# Altium schematic sheet CVTOP.SchDoc(CVTOP)
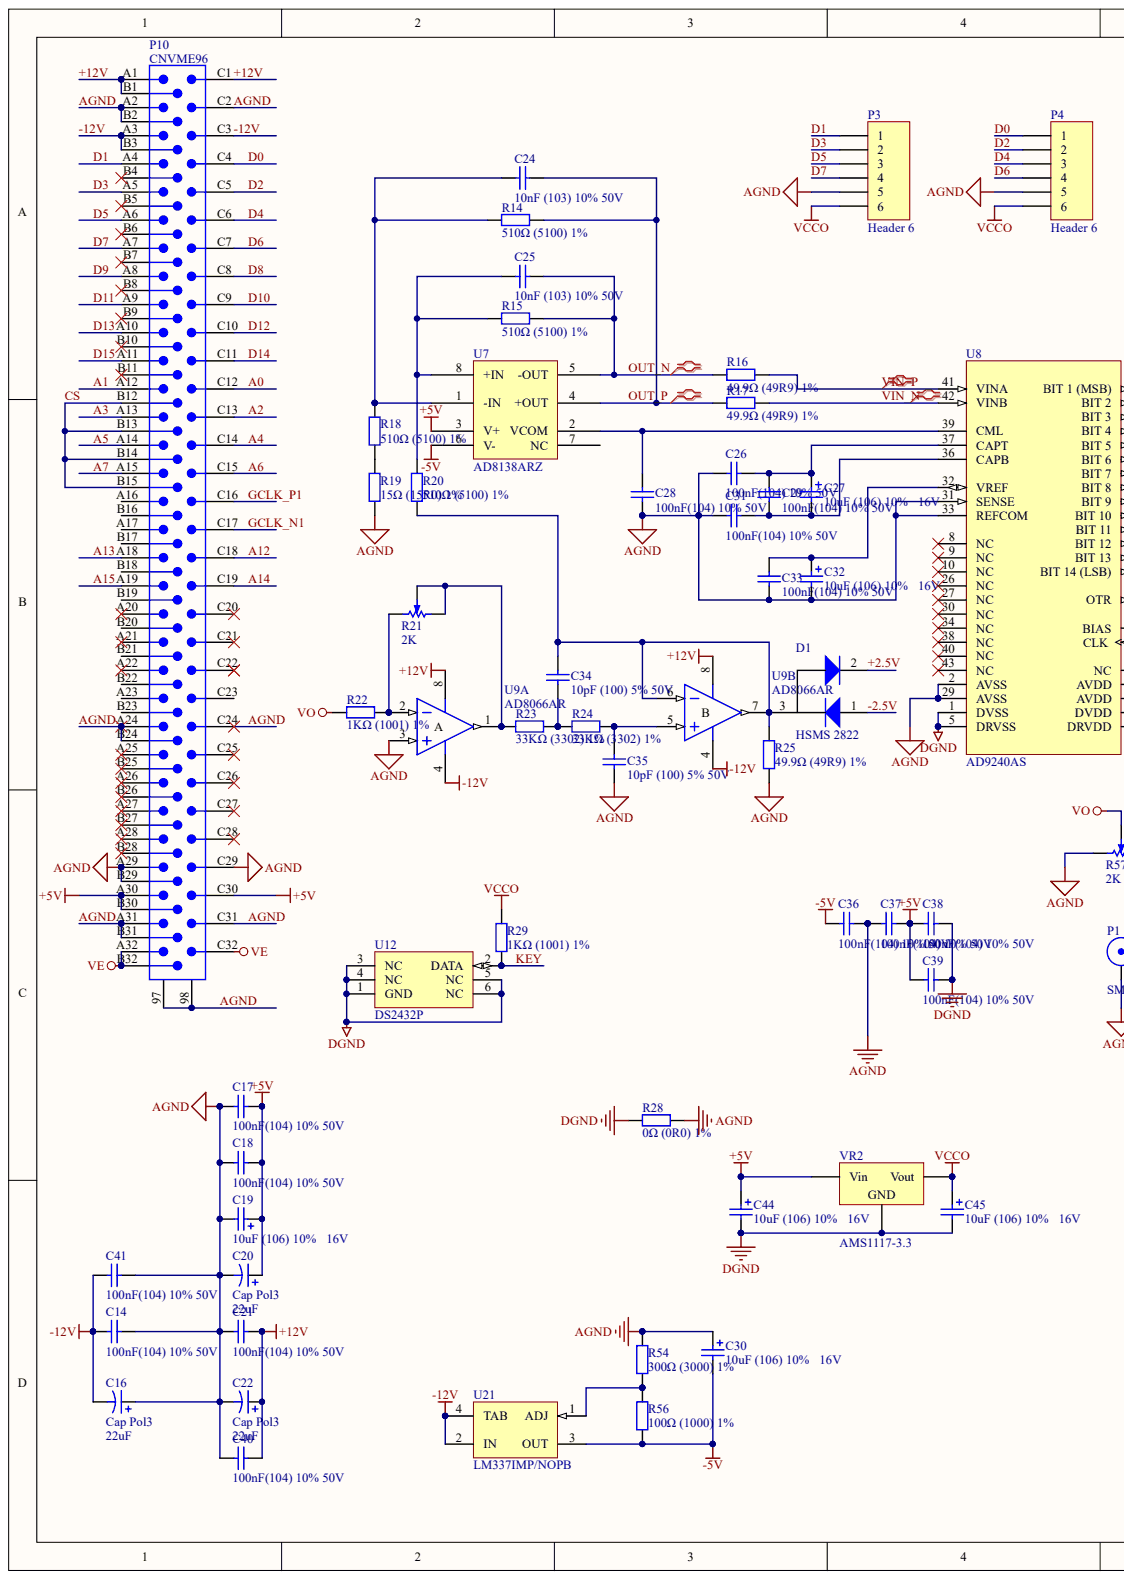
[diagram p0: CVTOP.SchDoc, left]
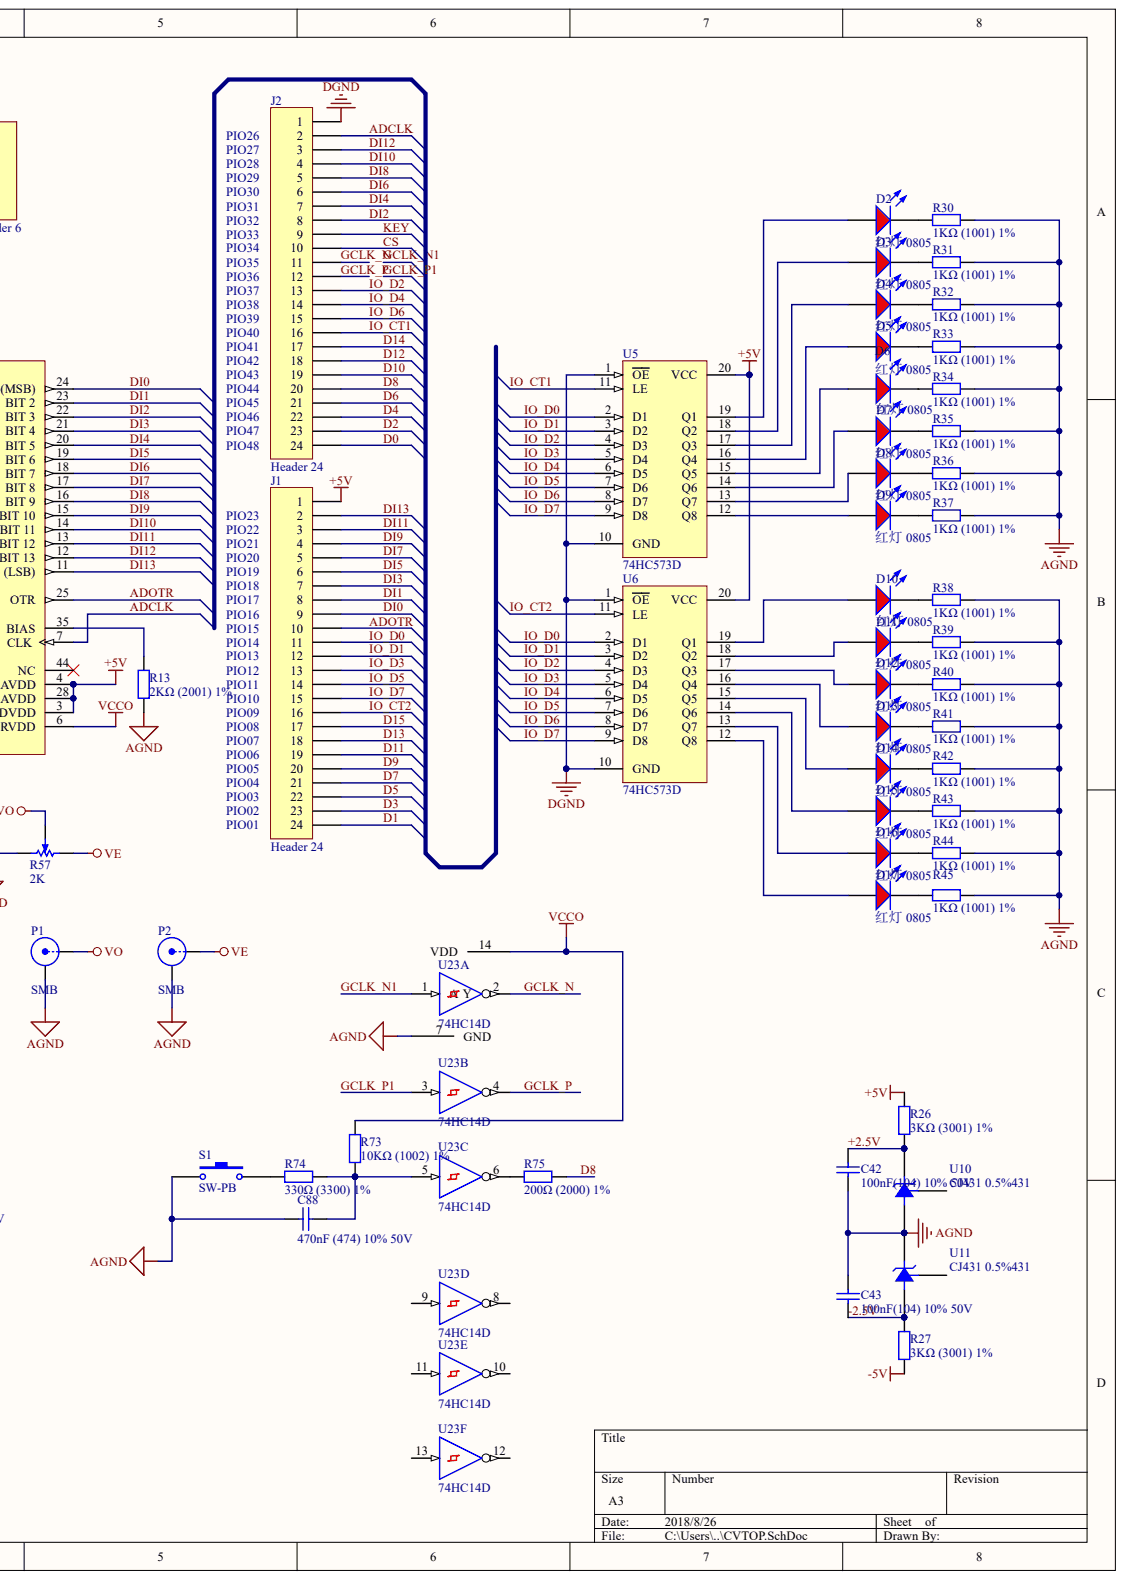
[diagram p1: CVTOP.SchDoc, right]

## Parts
C14  100nF(104) 10% 50V
C16  Cap Pol3  value 22uF
C17  100nF(104) 10% 50V
C18  100nF(104) 10% 50V
C19  10uF (106) ±10% 16V
C20  Cap Pol3  value 22uF
C21  100nF(104) 10% 50V
C22  Cap Pol3  value 22uF
C24  10nF (103) 10% 50V
C25  10nF (103) 10% 50V
C26  100nF(104) 10% 50V
C27  10uF (106) ±10% 16V
C28  100nF(104) 10% 50V
C29  100nF(104) 10% 50V
C30  10uF (106) ±10% 16V
C31  100nF(104) 10% 50V
C32  10uF (106) ±10% 16V
C33  100nF(104) 10% 50V
C34  10pF (100) 5% 50V
C35  10pF (100) 5% 50V
C36  100nF(104) 10% 50V
C37  100nF(104) 10% 50V
C38  100nF(104) 10% 50V
C39  100nF(104) 10% 50V
C40  100nF(104) 10% 50V
C41  100nF(104) 10% 50V
C42  100nF(104) 10% 50V
C43  100nF(104) 10% 50V
C44  10uF (106) ±10% 16V
C45  10uF (106) ±10% 16V
C88  470nF (474) 10% 50V
D1  HSMS 2822
D2  红灯 0805  Package: 0805
D3  红灯 0805  Package: 0805
D4  红灯 0805  Package: 0805
D5  红灯 0805  Package: 0805
D6  红灯 0805  Package: 0805
D7  红灯 0805  Package: 0805
D8  红灯 0805  Package: 0805
D9  红灯 0805  Package: 0805
D10  红灯 0805  Package: 0805
D11  红灯 0805  Package: 0805
D12  红灯 0805  Package: 0805
D13  红灯 0805  Package: 0805
D14  红灯 0805  Package: 0805
D15  红灯 0805  Package: 0805
D16  红灯 0805  Package: 0805
D17  红灯 0805  Package: 0805
J1  Header 24
J2  Header 24
P1  SMB
P2  SMB
P3  Header 6
P4  Header 6
P10  CNVME96
R13  2KΩ (2001) ±1%  (value not drawn)
R14  510Ω (5100) ±1%
R15  510Ω (5100) ±1%
R16  49.9Ω (49R9) ±1%
R17  49.9Ω (49R9) ±1%
R18  510Ω (5100) ±1%
R19  15Ω (15R0) ±1%  (value not drawn)
R20  510Ω (5100) ±1%
R21  2K
R22  1KΩ (1001) ±1%
R23  33KΩ (3302) ±1%  (value not drawn)
R24  33KΩ (3302) ±1%  (value not drawn)
R25  49.9Ω (49R9) ±1%
R26  3KΩ (3001) ±1%  (value not drawn)
R27  3KΩ (3001) ±1%  (value not drawn)
R28  0Ω (0R0) ±1%
R29  1KΩ (1001) ±1%  (value not drawn)
R30  1KΩ (1001) ±1%
R31  1KΩ (1001) ±1%  Package: 0805
R32  1KΩ (1001) ±1%  Package: 0805
R33  1KΩ (1001) ±1%  Package: 0805
R34  1KΩ (1001) ±1%  Package: 0805
R35  1KΩ (1001) ±1%  Package: 0805
R36  1KΩ (1001) ±1%  Package: 0805
R37  1KΩ (1001) ±1%  Package: 0805
R38  1KΩ (1001) ±1%
R39  1KΩ (1001) ±1%  Package: 0805
R40  1KΩ (1001) ±1%  Package: 0805
R41  1KΩ (1001) ±1%  Package: 0805
R42  1KΩ (1001) ±1%  Package: 0805
R43  1KΩ (1001) ±1%  Package: 0805
R44  1KΩ (1001) ±1%  Package: 0805
R45  1KΩ (1001) ±1%  Package: 0805
R54  300Ω (3000) ±1%
R56  100Ω (1000) ±1%
R57  2K
R73  10KΩ (1002) ±1%
R74  330Ω (3300) ±1%
R75  200Ω (2000) ±1%
S1  SW-PB
U5  74HC573D
U6  74HC573D
U7  AD8138ARZ
U8  AD9240AS
U9  AD8066AR  (parts A,B)
U10  CJ431 0.5%431
U11  CJ431 0.5%431
U12  DS2432P
U21  LM337IMP/NOPB
U23  74HC14D  (parts A,B,C,D,E,F)
VR2  AMS1117-3.3

## Nets
+5V: C17.2 C18.2 C19.1 C20.1 C37.1 C38.2 C39.2 C44.1 J1.1 P10.A30 P10.B30 P10.C30 R26.2 U5.20(VCC) U6.20(VCC) U7.3(V+) U8.3(DVDD) U8.4(AVDD) U8.28(AVDD) VR2.3
-5V: C30.2 C36.2 R27.1 R56.1 U7.6(V-) U21.3(OUT)
DGND: C38.1 C39.1 C44.2 C45.2 J2.1 R28.1 U5.1(OE) U5.10(GND) U6.1(OE) U6.10(GND) U8.1(DVSS) U8.5(DRVSS) U12.1(GND) U12.3(NC) U12.4(NC) U12.5(NC) U12.6(NC) VR2.1
VCCO: C45.1 P3.6 P4.6 R29.2 R73.1 U8.6(DRVDD) U23.14(VDD) VR2.2
VE: P2.1 P10.A32 P10.B32 P10.C32 R57.CCW
VO: P1.1 R22.1 R57.W
+2.5V: C42.1 D1.2 R26.1 U10.2
+12V: C21.2 C22.1 C40.1 P10.A1 P10.B1 P10.C1 U9.8
-2.5V: C43.2 D1.1 R27.2 U11.3
-12V: C14.1 C16.2 C41.2 P10.A3 P10.B3 P10.C3 U9.4 U21.2(IN) U21.4(TAB)
A0: P10.C12
A1: P10.A12
A2: P10.C13
A3: P10.A13
A4: P10.C14
A5: P10.A14
A6: P10.C15
A7: P10.A15
A12: P10.C18
A13: P10.A18
A14: P10.C19
A15: P10.A19
ADCLK: J2.2 U8.7(CLK)
ADOTR: J1.10 U8.25(OTR)
AGND: C14.2 C16.1 C17.1 C18.1 C19.2 C20.2 C21.1 C22.2 C26.2 C28.1 C30.1 C31.2 C32.2 C33.1 C35.1 C36.1 C37.2 C40.2 C41.1 C42.2 C43.1 C88.1 P1.2 P2.2 P3.5 P4.5 P10.97 P10.98 P10.A2 P10.A24 P10.A29 P10.A31 P10.B2 P10.B24 P10.B29 P10.B31 P10.C2 P10.C24 P10.C29 P10.C31 R13.1 R19.1 R25.1 R28.2 R30.2 R31.2 R32.2 R33.2 R34.2 R35.2 R36.2 R37.2 R38.2 R39.2 R40.2 R41.2 R42.2 R43.2 R44.2 R45.2 R54.2 R57.CW S1.1 U8.2(AVSS) U8.29(AVSS) U8.31(SENSE) U8.33(REFCOM) U9.3 U10.3 U11.2 U23.7(GND)
CS: J2.10 P10.B12 P10.B13 P10.B14 P10.B15
D0: J2.24 P4.1 P10.C4
D1: J1.24 P3.1 P10.A4
D2: J2.23 P4.2 P10.C5
D3: J1.23 P3.2 P10.A5
D4: J2.22 P4.3 P10.C6
D5: J1.22 P3.3 P10.A6
D6: J2.21 P4.4 P10.C7
D7: J1.21 P3.4 P10.A7
D8: J2.20 P10.C8 R75.2
D9: J1.20 P10.A8
D10: J2.19 P10.C9
D11: J1.19 P10.A9
D12: J2.18 P10.C10
D13: J1.18 P10.A10
D14: J2.17 P10.C11
D15: J1.17 P10.A11
DI0: J1.9 U8.24(BIT_1_(MSB))
DI1: J1.8 U8.23(BIT_2)
DI2: J2.8 U8.22(BIT_3)
DI3: J1.7 U8.21(BIT_4)
DI4: J2.7 U8.20(BIT_5)
DI5: J1.6 U8.19(BIT_6)
DI6: J2.6 U8.18(BIT_7)
DI7: J1.5 U8.17(BIT_8)
DI8: J2.5 U8.16(BIT_9)
DI9: J1.4 U8.15(BIT_10)
DI10: J2.4 U8.14(BIT_11)
DI11: J1.3 U8.13(BIT_12)
DI12: J2.3 U8.12(BIT_13)
DI13: J1.2 U8.11(BIT_14_(LSB))
GCLK_N: J2.11 U23.2(Y)
GCLK_N1: P10.C17 U23.1(A)
GCLK_P: J2.12 U23.4
GCLK_P1: P10.C16 U23.3
IO_CT1: J2.16 U5.11(LE)
IO_CT2: J1.16 U6.11(LE)
IO_D0: J1.11 U5.2(D1) U6.2(D1)
IO_D1: J1.12 U5.3(D2) U6.3(D2)
IO_D2: J2.13 U5.4(D3) U6.4(D3)
IO_D3: J1.13 U5.5(D4) U6.5(D4)
IO_D4: J2.14 U5.6(D5) U6.6(D5)
IO_D5: J1.14 U5.7(D6) U6.7(D6)
IO_D6: J2.15 U5.8(D7) U6.8(D7)
IO_D7: J1.15 U5.9(D8) U6.9(D8)
KEY: J2.9 R29.1 U12.2(DATA)
OUT_N: C25.2 R15.2 R16.1 U7.5(-OUT)
OUT_P: C24.2 R14.2 R17.1 U7.4(+OUT)
VIN_N: R17.2 U8.42(VINB)
VIN_P: R16.2 U8.41(VINA)
NetC24_1: C24.1 R14.1 R18.2 U7.1(-IN)
NetC25_1: C25.1 R15.1 R20.2 U7.8(+IN)
NetC26_1: C26.1 C27.1 C29.2 U8.37(CAPT)
NetC27_2: C27.2 C29.1 C31.1 U8.36(CAPB)
NetC28_2: C28.2 U7.2(VCOM) U8.39(CML)
NetC32_1: C32.1 C33.2 U8.32(VREF)
NetC34_1: C34.1 R23.2 R24.1
NetC34_2: C34.2 D1.3 R20.1 R25.2 U9.6 U9.7
NetC35_2: C35.2 R24.2 U9.5
NetC88_2: C88.2 R73.2 R74.2 U23.5
NetD2_A: D2.A U5.19(Q1)
NetD2_K: D2.K R30.1
NetD3_A: D3.A U5.18(Q2)
NetD3_K: D3.K R31.1
NetD4_A: D4.A U5.17(Q3)
NetD4_K: D4.K R32.1
NetD5_A: D5.A U5.16(Q4)
NetD5_K: D5.K R33.1
NetD6_A: D6.A U5.15(Q5)
NetD6_K: D6.K R34.1
NetD7_A: D7.A U5.14(Q6)
NetD7_K: D7.K R35.1
NetD8_A: D8.A U5.13(Q7)
NetD8_K: D8.K R36.1
NetD9_A: D9.A U5.12(Q8)
NetD9_K: D9.K R37.1
NetD10_A: D10.A U6.19(Q1)
NetD10_K: D10.K R38.1
NetD11_A: D11.A U6.18(Q2)
NetD11_K: D11.K R39.1
NetD12_A: D12.A U6.17(Q3)
NetD12_K: D12.K R40.1
NetD13_A: D13.A U6.16(Q4)
NetD13_K: D13.K R41.1
NetD14_A: D14.A U6.15(Q5)
NetD14_K: D14.K R42.1
NetD15_A: D15.A U6.14(Q6)
NetD15_K: D15.K R43.1
NetD16_A: D16.A U6.13(Q7)
NetD16_K: D16.K R44.1
NetD17_A: D17.A U6.12(Q8)
NetD17_K: D17.K R45.1
NetR13_2: R13.2 U8.35(BIAS)
NetR18_1: R18.1 R19.2
NetR21_CCW: R21.CCW R21.W R23.1 U9.1
NetR21_CW: R21.CW R22.2 U9.2
NetR54_1: R54.1 R56.2 U21.1(ADJ)
NetR74_1: R74.1 S1.2
NetR75_1: R75.1 U23.6
N00176:

Not connected: P10 A16 A17 A20 A21 A22 A23 A25 A26 A27 A28 B4 B5 B6 B7 B8 B9 B10 B11 B16 B17 B18 B19 B20 B21 B22 B23 B25 B26 B27 B28 C20 C21 C22 C23 C25 C26 C27 C28; U7 7(NC); U8 8(NC) 9(NC) 10(NC) 26(NC) 27(NC) 30(NC) 34(NC) 38(NC) 40(NC) 43(NC) 44(NC); U10 1; U11 1; U23 8 9 10 11 12 13

## Library data of the parts
C20 C22 = (no symbol name): Value: 22uF; Published: 8-Jun-2000; LatestRevisionDate: 29-May-2009; LatestRevisionNote: IPC-7351 Footprint Added.; PackageReference: C0805; PackageDescription: Chip Capacitor; Publisher: Altium Limited
P10 = (no symbol name): Text Field6: Alternate Layout Available; Text Field7: Published 14-Feb-2002
U12 = (no symbol name): Note: Pin numbers 3-6 are Not Connected (NC); Published: 13-Oct-2000; LatestRevisionDate: 17-Jul-2002; LatestRevisionNote: Re-released for DXP Platform.; DatasheetVersion: 21-Oct-1999; PackageVersion: 1999; PackageReference: TSOC6; PackageDescription: Small Outline; 6 C-Bend Leads; Body Width 3.8 mm; Pitch 1.27 mm; ComponentLink1Description: Manufacturer Link; ComponentLink1URL: http://www.maxim-ic.com/; ComponentLink2Description: Datasheet; ComponentLink2URL: http://pdfserv.maxim-ic.com/en/ds/DS2430A.pdf; Publisher: Altium Limited
U21 = (no symbol name): Manufacturer: National Semiconductor; PackageTopMarking: XYTT*N02A; PowerWise: N; CompType: LDO IC; Published: 1-Mar-2010; DatasheetVersion: Nov-2004; PackageVersion: revB, Apr-2003; PackageReference: MP04A; PackageDescription: Molded SOT-223, 6.50 x 3.56 x 1.6mm Body, 4 Lead, 2.3mm Pitch; Supplier 1: Digi-Key; Supplier Part Number 1: LM337IMPTR-ND; Supplier 2: Digi-Key; Supplier Part Number 2: LM337IMPCT-ND; Supplier 3: Digi-Key; Supplier Part Number 3: LM337IMPDKR-ND; Supplier 4: Newark; Supplier Part Number 4: 41K4742; PartNumber: LM337IMP/NOPB; RoHS: Y; PinCount: 4; Code_JEDEC: TO-261-AA; Code_IPC: SOT223-4; ComponentLink1Description: LM337 Datasheet; ComponentLink1URL: http://www.national.com/ds/LM/LM337.pdf; ComponentLink2Description: LM337 Web Page; ComponentLink2URL: http://www.national.com/pf/LM/LM337.html; ComponentLink3Description: MP04A Package Page; ComponentLink3URL: http://www.national.com/packaging/folders/mp04a.html; Publisher: Altium Limited
C14 C16-C19 C21 C24-C45 C88 D1-D17 J1 J2 P1-P4 R13-R45 R54 R56 R57 R73-R75 S1 U5-U11 U23 VR2 = (no symbol name)
  C14 C17 C18 C21 C26 C28 C29 C31 C33 C36-C43: ComponentLink1Description: 供应商链接; ComponentLink1URL: http://www.szlcsc.com/product/details_50686.html; Package: 0805; Supplier: LC; SuppliersPartNumber: C49678
  C16: Published: 8-Jun-2000; LatestRevisionDate: 29-May-2009; LatestRevisionNote: IPC-7351 Footprint Added.; PackageReference: C0805; PackageDescription: Chip Capacitor
  C19 C27 C30 C32 C44 C45: ComponentLink1Description: 供应商链接; ComponentLink1URL: http://www.szlcsc.com/product/details_7643.html; Package: 3216; Supplier: LC; SuppliersPartNumber: C7171
  C24 C25: ComponentLink1Description: 供应商链接; ComponentLink1URL: http://www.szlcsc.com/product/details_2062.html; Package: 0805; Supplier: LC; SuppliersPartNumber: C1710
  C34 C35: ComponentLink1Description: 供应商链接; ComponentLink1URL: http://www.szlcsc.com/product/details_2137.html; Package: 0805; Supplier: LC; SuppliersPartNumber: C1785
  C88: ComponentLink1Description: 供应商链接; ComponentLink1URL: http://www.szlcsc.com/product/details_14623.html; Package: 0805; Supplier: LC; SuppliersPartNumber: C13967
  D1: ComponentLink1Description: 供应商链接; ComponentLink1URL: http://www.szlcsc.com/product/details_2877.html; Package: SOT-23; Supplier: LC; SuppliersPartNumber: C2500
  D2-D17: ComponentLink1Description: 供应商链接; ComponentLink1URL: http://www.szlcsc.com/product/details_2668.html; Supplier: LC; SuppliersPartNumber: C2295
  J1 J2 P1-P4: LatestRevisionDate: 17-Jul-2002; LatestRevisionNote: Re-released for DXP Platform.
  R13: ComponentLink1Description: 供应商链接; ComponentLink1URL: http://www.szlcsc.com/product/details_18292.html; Package: 0805; Supplier: LC; SuppliersPartNumber: C17604
  R14 R15 R18 R20: ComponentLink1Description: 供应商链接; ComponentLink1URL: http://www.szlcsc.com/product/details_18422.html; Package: 0805; Supplier: LC; SuppliersPartNumber: C17734
  R16 R17 R25: ComponentLink1Description: 供应商链接; ComponentLink1URL: http://www.szlcsc.com/product/details_18408.html; Package: 0805; Supplier: LC; SuppliersPartNumber: C17720
  R19: ComponentLink1Description: 供应商链接; ComponentLink1URL: http://www.szlcsc.com/product/details_18168.html; Package: 0805; Supplier: LC; SuppliersPartNumber: C17480
  R21 R57: Published: 17-Dec-2003; DatasheetVersion: Oct-2003; PackageReference: POT4MM-2; PackageDescription: Square Trimming Potentiometer; ComponentLink1Description: Manufacturer Link; ComponentLink1URL: http://bourns.com/2/search.html
  R22 R29 R30 R38: ComponentLink1Description: 供应商链接; ComponentLink1URL: http://www.szlcsc.com/product/details_18201.html; Package: 0805; Supplier: LC; SuppliersPartNumber: C17513
  R23 R24: ComponentLink1Description: 供应商链接; ComponentLink1URL: http://www.szlcsc.com/product/details_18321.html; Package: 0805; Supplier: LC; SuppliersPartNumber: C17633
  R26 R27: ComponentLink1Description: 供应商链接; ComponentLink1URL: http://www.szlcsc.com/product/details_18349.html; Package: 0805; Supplier: LC; SuppliersPartNumber: C17661
  R28: ComponentLink1Description: 供应商链接; ComponentLink1URL: http://www.szlcsc.com/product/details_18165.html; Package: 0805; Supplier: LC; SuppliersPartNumber: C17477
  R31-R37 R39-R45: ComponentLink1Description: 供应商链接; ComponentLink1URL: http://www.szlcsc.com/product/details_18201.html; Supplier: LC; SuppliersPartNumber: C17513
  R54: ComponentLink1Description: 供应商链接; ComponentLink1URL: http://www.szlcsc.com/product/details_18305.html; Package: 0805; Supplier: LC; SuppliersPartNumber: C17617
  R56: ComponentLink1Description: 供应商链接; ComponentLink1URL: http://www.szlcsc.com/product/details_18096.html; Package: 0805; Supplier: LC; SuppliersPartNumber: C17408
  R73: ComponentLink1Description: 供应商链接; ComponentLink1URL: http://www.szlcsc.com/product/details_18102.html; Package: 0805; Supplier: LC; SuppliersPartNumber: C17414
  R74: ComponentLink1Description: 供应商链接; ComponentLink1URL: http://www.szlcsc.com/product/details_18318.html; Package: 0805; Supplier: LC; SuppliersPartNumber: C17630
  R75: ComponentLink1Description: 供应商链接; ComponentLink1URL: http://www.szlcsc.com/product/details_18228.html; Package: 0805; Supplier: LC; SuppliersPartNumber: C17540
  S1: Published: 24-Mar-1999; LatestRevisionDate: 17-Jul-2002; LatestRevisionNote: Re-released for DXP Platform.; PackageReference: SPST-2; PackageDescription: Switch; 2 Leads
  U5 U6: ComponentLink1Description: 供应商链接; ComponentLink1URL: http://www.szlcsc.com/product/details_6059.html; Package: SOIC-20_300mil; Supplier: LC; SuppliersPartNumber: C5608
  U8: Published: 26-May-2004; LatestRevisionDate: 13-Apr-2006; LatestRevisionNote: IPC-7351 Footprint Added.; DatasheetVersion: Rev. A; PackageVersion: Rev. A; PackageReference: S-44-MQFP; PackageDescription: 44-Pin Metric Quad Flat Pack 0.8 mm Pitch; Code_IPC: QFP80P1320X1320-44; ComponentLink1Description: Manufacturer Link; ComponentLink1URL: http://www.analog.com/en/prod/0%2C2877%2CAD9240%2C00.html; ComponentLink2Description: Datasheet; ComponentLink2URL: http://www.analog.com/UploadedFiles/Data_Sheets/417954540AD9240_a.pdf
  U9: Published: 8-Jun-2000; LatestRevisionDate: 13-Apr-2006; LatestRevisionNote: IPC-7351 Footprint Added.; DatasheetVersion: REV. 0 1997; PackageVersion: revA; PackageReference: SO-8; PackageDescription: 8-Pin Small Outline Integrated Circuit 1.27 mm Pitch; Code_JEDEC: MS-012-AA; Code_IPC: SOIC127P600-8; ComponentLink1Description: Manufacturer Link; ComponentLink1URL: http://www.analog.com/en/prod/0,,759_786_AD8055%2C00.html; ComponentLink2Description: Datasheet; ComponentLink2URL: http://www.analog.com/UploadedFiles/Data_Sheets/40402558757264AD8055_6_i.pdf
  U10 U11: ComponentLink1Description: 供应商链接; ComponentLink1URL: http://www.szlcsc.com/product/details_3500.html; Package: SOT-23; Supplier: LC; SuppliersPartNumber: C3113
  U23: ComponentLink1Description: 供应商链接; ComponentLink1URL: http://www.szlcsc.com/product/details_6056.html; Package: SOIC-14_150mil; Supplier: LC; SuppliersPartNumber: C5605
  VR2: ComponentLink1Description: 供应商链接; ComponentLink1URL: http://www.szlcsc.com/product/details_6652.html; Package: SOT-223; Supplier: LC; SuppliersPartNumber: C6186
  C16 J1 J2 P1-P4 R21 R57 S1 U8 U9: Publisher: Altium Limited
